# Altium schematic sheet SWITCHING.SchDoc
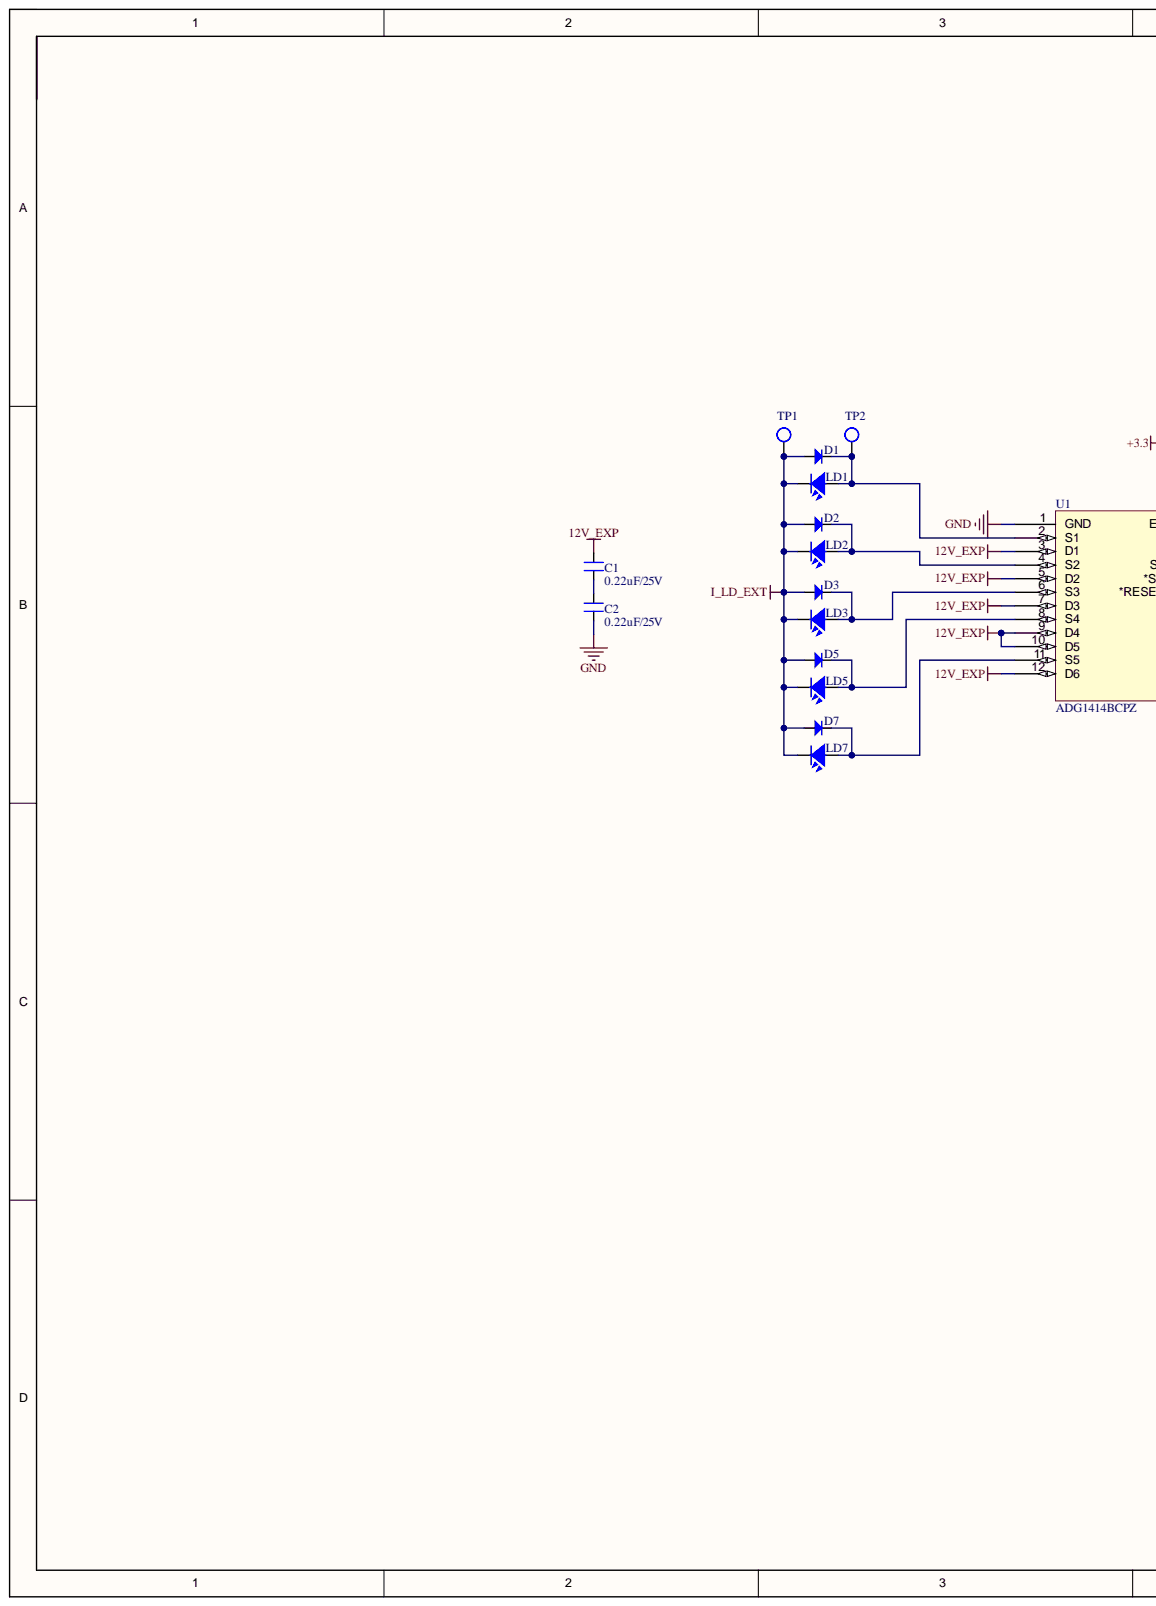
[diagram p0: SWITCHING.SchDoc, left]
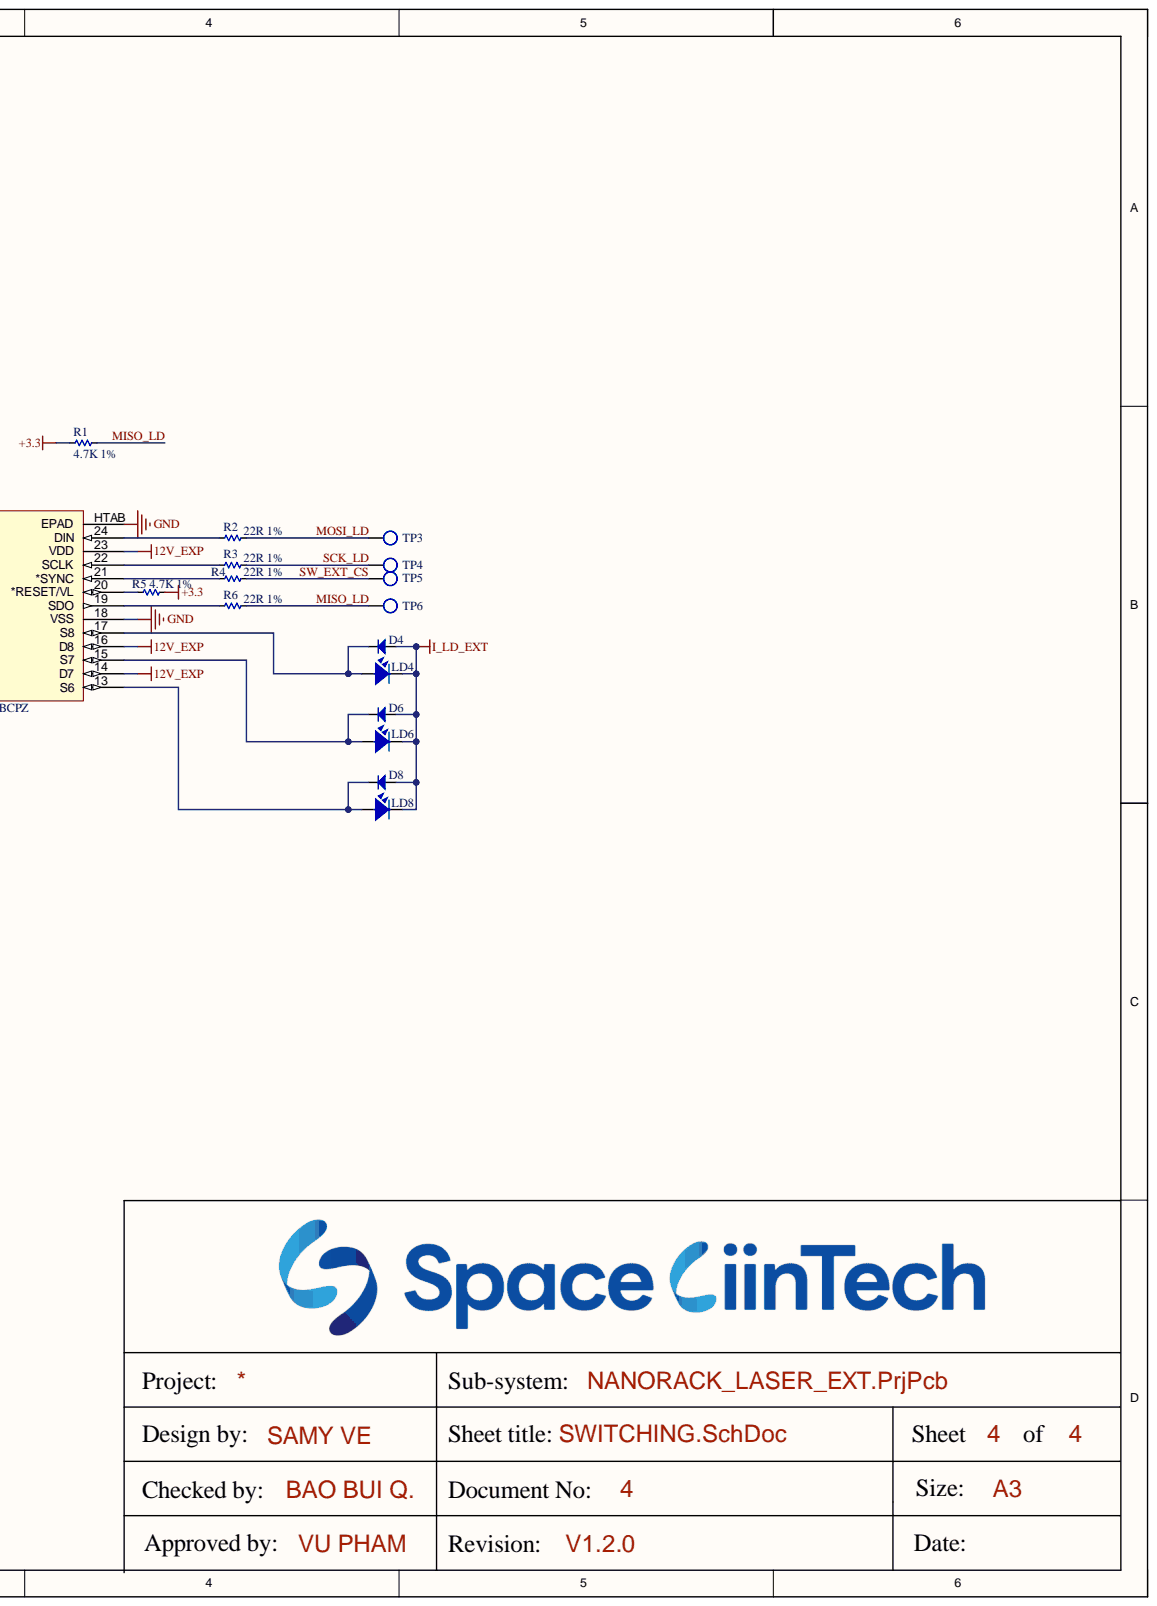
[diagram p1: SWITCHING.SchDoc, right]

## Parts
C1  0.22uF/25V  [CN-SMD-0603]
C2  0.22uF/25V  [CN-SMD-0603]
D1  1N4148W-TP  [DIO-SMD-123]  (value not drawn)
D2  1N4148W-TP  [DIO-SMD-123]  (value not drawn)
D3  1N4148W-TP  [DIO-SMD-123]  (value not drawn)
D4  1N4148W-TP  [DIO-SMD-123]  (value not drawn)
D5  1N4148W-TP  [DIO-SMD-123]  (value not drawn)
D6  1N4148W-TP  [DIO-SMD-123]  (value not drawn)
D7  1N4148W-TP  [DIO-SMD-123]  (value not drawn)
D8  1N4148W-TP  [DIO-SMD-123]  (value not drawn)
LD1  LaserDiode  [LASER_SOCKET]  (value not drawn)
LD2  LaserDiode  [LASER_SOCKET]  (value not drawn)
LD3  LaserDiode  [LASER_SOCKET]  (value not drawn)
LD4  LaserDiode  [LASER_SOCKET]  (value not drawn)
LD5  LaserDiode  [LASER_SOCKET]  (value not drawn)
LD6  LaserDiode  [LASER_SOCKET]  (value not drawn)
LD7  LaserDiode  [LASER_SOCKET]  (value not drawn)
LD8  LaserDiode  [LASER_SOCKET]  (value not drawn)
R1  4.7K 1%  [RES-SMD-0603]
R2  22R 1%  [RES-SMD-0603]
R3  22R 1%  [RES-SMD-0603]
R4  22R 1%  [RES-SMD-0603]
R5  4.7K 1%  [RES-SMD-0603]
R6  22R 1%  [RES-SMD-0603]
TP1  Test-Point  [TESTPOINT]  (value not drawn)
TP2  Test-Point  [TESTPOINT]  (value not drawn)
TP3  Test-Point  [TESTPOINT]  (value not drawn)
TP4  Test-Point  [TESTPOINT]  (value not drawn)
TP5  Test-Point  [TESTPOINT]  (value not drawn)
TP6  Test-Point  [TESTPOINT]  (value not drawn)
U1  ADG1414BCPZ  [ADG1414BCPZ]

## Nets
12V_EXP: C1.2 U1.3(D1) U1.5(D2) U1.7(D3) U1.9(D4) U1.10(D5) U1.12(D6) U1.14(D7) U1.16(D8) U1.23(VDD)
+3.3: R1.1 R5.1
GND: C2.1 U1.1(GND) U1.18(VSS) U1.HTAB(EPAD)
I_LD_EXT: D1.1 D2.1 D3.1 D4.1 D5.1 D6.1 D7.1 D8.1 LD1.3 LD2.3 LD3.3 LD4.3 LD5.3 LD6.3 LD7.3 LD8.3 TP1.1
MISO_LD: R1.2 R6.1 TP6.1
MOSI_LD: R2.1 TP3.1
SCK_LD: R3.1 TP4.1
SW_EXT_CS: R4.1 TP5.1
NetC1_1: C1.1 C2.2
NetD1_2: D1.2 LD1.1 TP2.1 U1.2(S1)
NetD2_2: D2.2 LD2.1 U1.4(S2)
NetD3_2: D3.2 LD3.1 U1.6(S3)
NetD4_2: D4.2 LD4.1 U1.17(S8)
NetD5_2: D5.2 LD5.1 U1.8(S4)
NetD6_2: D6.2 LD6.1 U1.15(S7)
NetD7_2: D7.2 LD7.1 U1.11(S5)
NetD8_2: D8.2 LD8.1 U1.13(S6)
NetR2_2: R2.2 U1.24(DIN)
NetR3_2: R3.2 U1.22(SCLK)
NetR4_2: R4.2 U1.21(*SYNC)
NetR5_2: R5.2 U1.20(*RESET/VL)
NetR6_2: R6.2 U1.19(SDO)

## Library data of the parts
C1 C2 = CN: CAP CER 0.22UF 25V X7R 0603; Value: 33pF; Component Kind: Standard; Library Name: Library.SchLib
D1-D8 = Diode SMD: DIODE STANDARD 100V 150MA SOD123; Code - JEDEC: DO-214-AB; Value: 1N5007; Component Kind: Standard; Library Name: Library.SchLib; Published: 8-Jun-2000; Revision: July-2002: Re-released for DXP Platform.; Publisher: Altium Limited
LD1-LD8 = LASER: Component Kind: Standard; Library Name: Library.SchLib
R1 R5 = Res-SMD: RES SMD 4.7K OHM 1% 1/10W 0603; Manufacturer: Generic Components; Class: Passive; Component Kind: Standard; Library Name: Library.SchLib; Published: 08/06/2000; Publisher: Altium Hobart Technology Centre
R2-R4 R6 = Res-SMD: RES SMD 22 OHM 1% 1/10W 0603; Manufacturer: Generic Components; Class: Passive; Component Kind: Standard; Library Name: Library.SchLib; Published: 08/06/2000; Publisher: Altium Hobart Technology Centre
U1 = ADG1414BCPZ: IC SW SPST-NOX8 11.5OHM 24LFCSP; Component Kind: Standard; Library Name: Library.SchLib
TP1-TP6 = Test-Point: Component Kind: Standard; Library Name: Library.SchLib
